# Altium schematic sheet Sheet1.SchDoc(Sheet1)
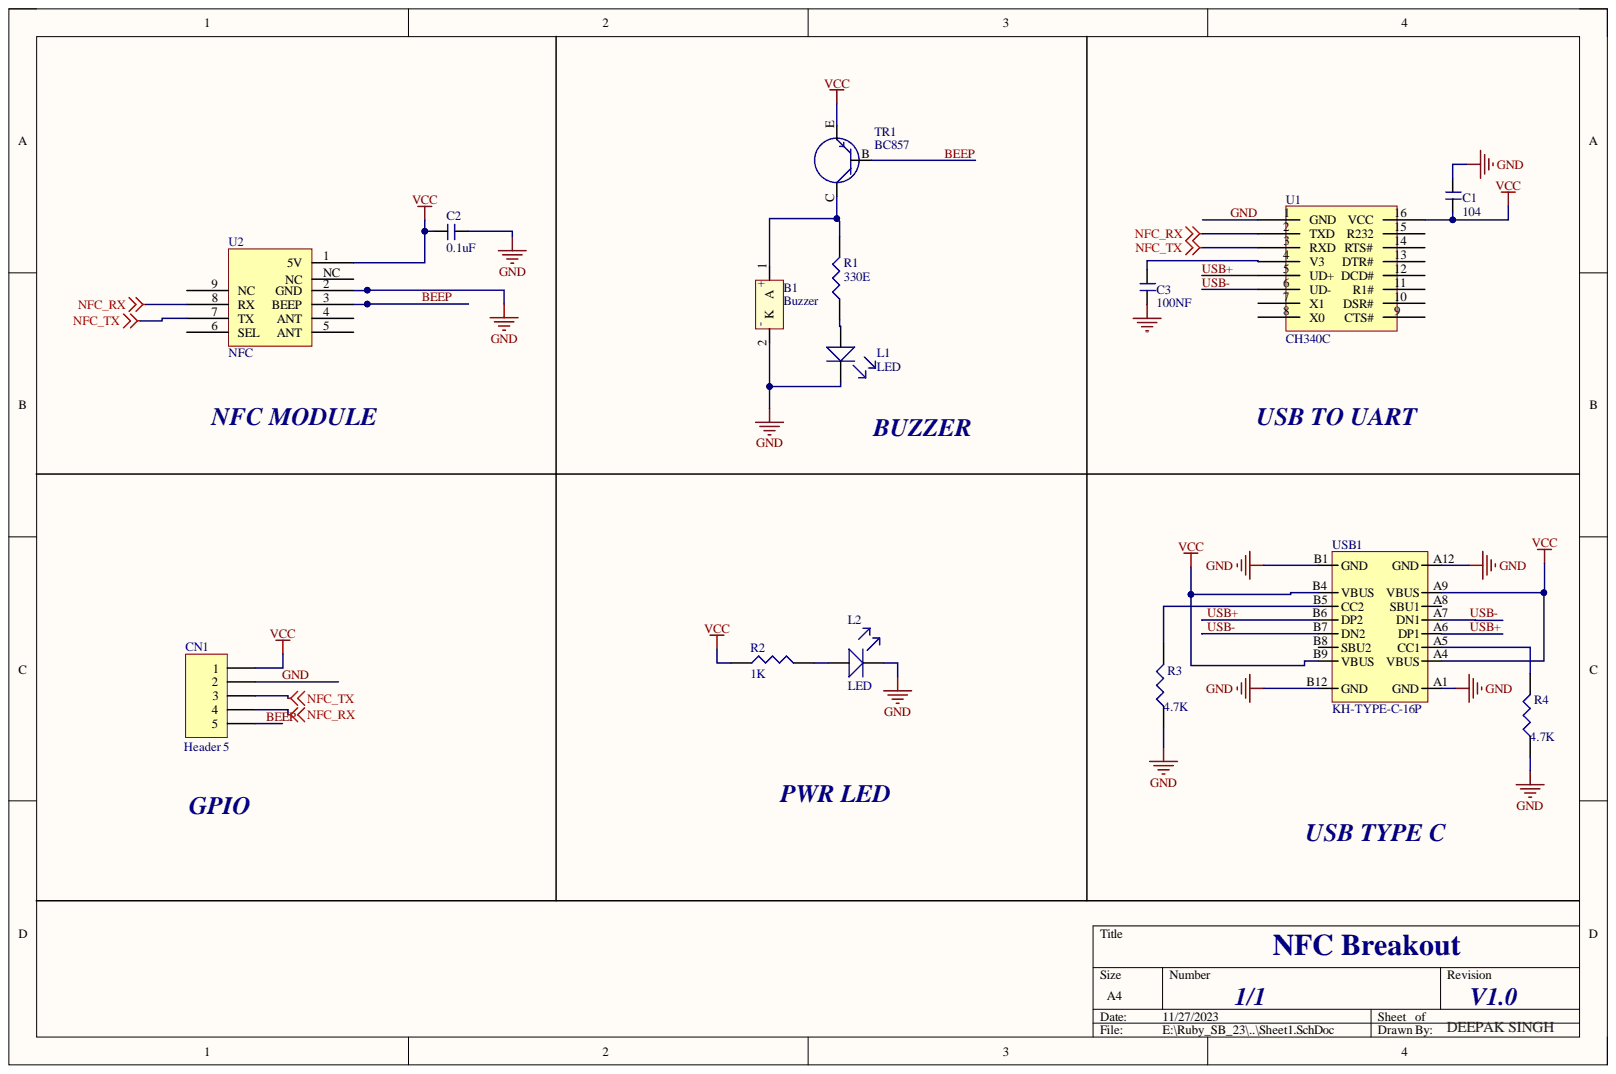
[diagram p0: Sheet1.SchDoc]

## Parts
B1  Buzzer  [BUZZER_9MM]
C1  104  [CAP_0603_NONPOLAR]
C2  0.1uF  [CAP_0603_NONPOLAR]
C3  100NF  [CAP_0603_NONPOLAR]
CN1  Header 5  [5P_TH2.54MM]
L1  LED  [LED_0603_neg]
L2  LED  [LED_0603_neg]
R1  330E  [0603]
R2  1K  [0603]
R3  4.7K  [R_0603]
R4  4.7K  [R_0603]
TR1  BC857  [SOT-23]
U1  CH340C  [SOIC_16]
U2  NFC  [RFID]
USB1  KH-TYPE-C-16P  [USB TYPE _C]

## Nets
NFC_RX: CN1.4 U1.2(TXD) U2.8(RX)
NFC_TX: CN1.3 U1.3(RXD) U2.7(TX)
VCC: C1.1 C2.1 CN1.1 R2.1 TR1.E U1.16(VCC) U2.1(5V) USB1.A4(VBUS) USB1.A9(VBUS) USB1.B4(VBUS) USB1.B9(VBUS)
BEEP: CN1.5 TR1.B U2.3(BEEP)
GND: B1.2 C1.2 C2.2 C3.1 CN1.2 L1.K L2.K R3.1 R4.1 U1.1(GND) U2.2(GND) USB1.A1(GND) USB1.A12(GND) USB1.B1(GND) USB1.B12(GND)
USB+: U1.5(UD+) USB1.A6(DP1) USB1.B6(DP2)
USB-: U1.6(UD-) USB1.A7(DN1) USB1.B7(DN2)
NetB1_1: B1.1 R1.2 TR1.C
NetC3_2: C3.2 U1.4(V3)
NetL1_A: L1.A R1.1
NetL2_A: L2.A R2.2
NetR3_2: R3.2 USB1.B5(CC2)
NetR4_2: R4.2 USB1.A5(CC1)

Not connected: U1 7(X1) 8(X0) 9(CTS#) 10(DSR#) 11(R1#) 12(DCD#) 13(DTR#) 14(RTS#) 15(R232); U2 4(ANT) 5(ANT) 6(SEL) 9(NC) NC; USB1 A8(SBU1) B8(SBU2)

## Library data of the parts
C1-C3 = CAP_NONPOLAR: Component Kind: Standard; Library Name: dxp_pasl_lib.IntLib
CN1 = Header 5: Header, 5-Pin; Component Kind: Standard; LatestRevisionDate: 17-Jul-2002; LatestRevisionNote: Re-released for DXP Platform.; Library Name: Miscellaneous Connectors.IntLib; Signal Integrity: Connector; Publisher: Altium Limited
R1-R4 = RES: Component Kind: Standard; Library Name: dxp_pasl_lib.IntLib
TR1 = TRANSISTER_PNP: Component Kind: Standard; Library Name: dxp_pasl_lib.IntLib
U2 = NFC: 8MHz XO (Standard) CMOS Oscillator 3.3V Standby (Power Down) 4-SMD, No Lead; Component Kind: Standard; Library Name: MIX.SchLib
USB1 = USB TYPE-C KH-TYPE-C-16P: 3A 1 Surface Mount 16 Female -40?~+85? Type-C SMD USB Connectors ROHS #  C709357; Component Kind: Standard; ComponentLink2Description: New link; ComponentLink2URL: https://lcsc.com/product-detail/USB-Connectors_Shenzhen-Kinghelm-Elec-KH-TYPE-C-16P_C709357.html; Supplier 1: LCSC; Supplier Part Number 1: C165948; Library Name: dxp_pasl_lib.IntLib
B1 = Buzzer: Component Kind: Standard; Library Name: dxp_pasl_lib.IntLib
L1 L2 = LED: Component Kind: Standard; Library Name: dxp_pasl_lib.IntLib
U1 = CH340C: Component Kind: Standard; Library Name: dxp_pasl_lib.IntLib
